# Altium schematic sheet RS-485.SchDoc(RS-485)
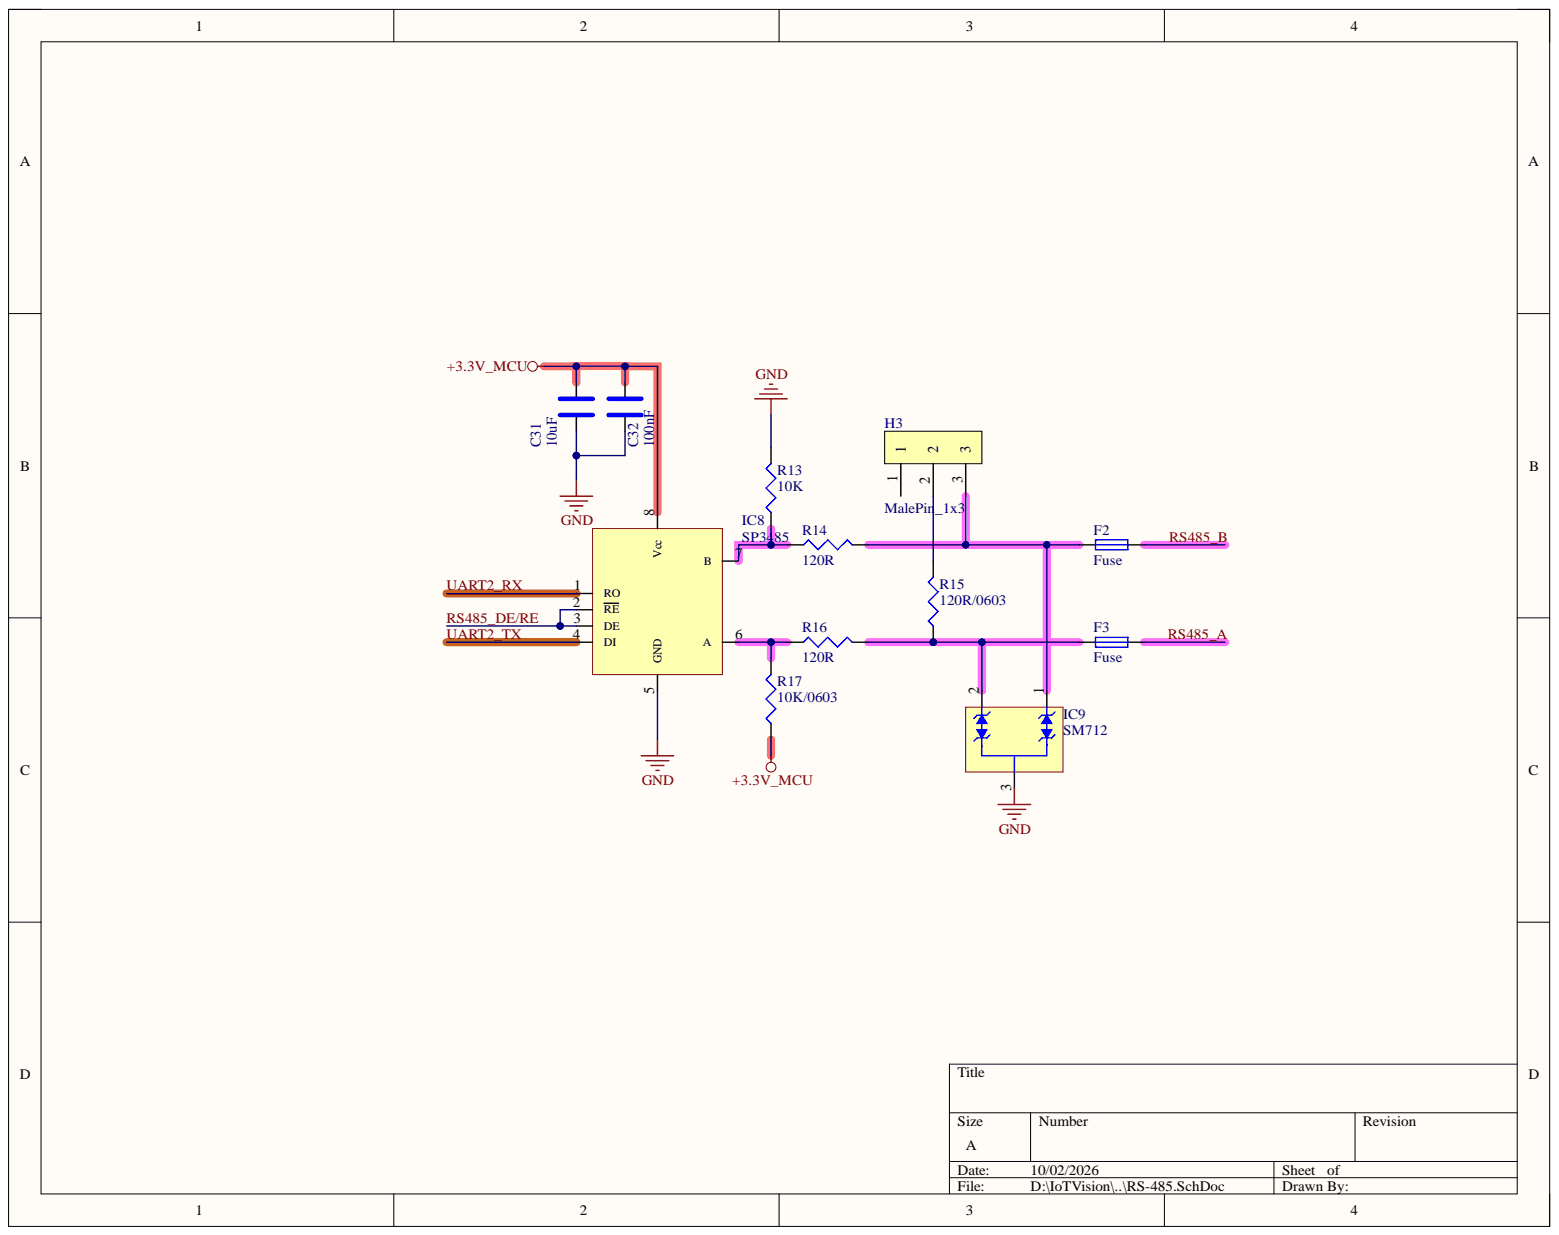
[diagram p0: RS-485.SchDoc]

## Parts
C31  10uF  [C_0603]
C32  100nF  [C_0402]
F2  Fuse  [FUSE_0805_2.2x1.5mm]
F3  Fuse  [FUSE_0805_2.2x1.5mm]
H3  MalePin_1x3  [MALEPIN_1x3]
IC8  SP3485  [SOIC8_NoPowerPad]
IC9  SM712  [SOT23-3]
R13  10K  [R_0603]
R14  120R  [R_0603]
R15  120R/0603  [R_0603]
R16  120R  [R_0603]
R17  10K/0603  [R_0603]

## Nets
+3.3V_MCU: C31.1 C32.1 IC8.8(Vcc) R17.1
GND: C31.2 C32.2 IC8.5(GND) IC9.3 R13.2
RS485_A: F3.2
RS485_B: F2.2
RS485_DE/RE: IC8.2(RE) IC8.3(DE)
UART2_RX: IC8.1(RO)
UART2_TX: IC8.4(DI)
NetF2_1: F2.1 H3.3 IC9.1 R14.2
NetF3_1: F3.1 IC9.2 R15.1 R16.2
NetH3_2: H3.2 R15.2
NetIC8_6: IC8.6(A) R16.1 R17.2
NetIC8_7: IC8.7(B) R13.1 R14.1

Not connected: H3 1

## Library data of the parts
C31 C32 = C: Component Kind: Standard; Description: C; Library Name: LNDB_Schlib.SchLib
IC8 = SP3485: Half-Duplex RS-485 Transceiver; Component Kind: Standard; Library Name: LNDB_Schlib.SchLib
IC9 = SM712: The SM712 TVS Diode Array is designed to protect RS-485 applications with asymmetrical working voltages (-7V to 12V) from damage due to electrostatic discharge (ESD), electrical fast transients (EFT), and lightning induced surges.; Component Kind: Standard; Library Name: LNDB_Schlib.SchLib
R13-R17 = R: Component Kind: Standard; Description: R; Library Name: LNDB_Schlib.SchLib
F2 F3 = Fuse: Component Kind: Standard; Description: Fuse; Library Name: LNDB_Schlib.SchLib
H3 = MalePin_1x3: Component Kind: Standard; Library Name: UY_Schlib.SchLib
